# Altium schematic sheet FPGA_Power_Config.SchDoc
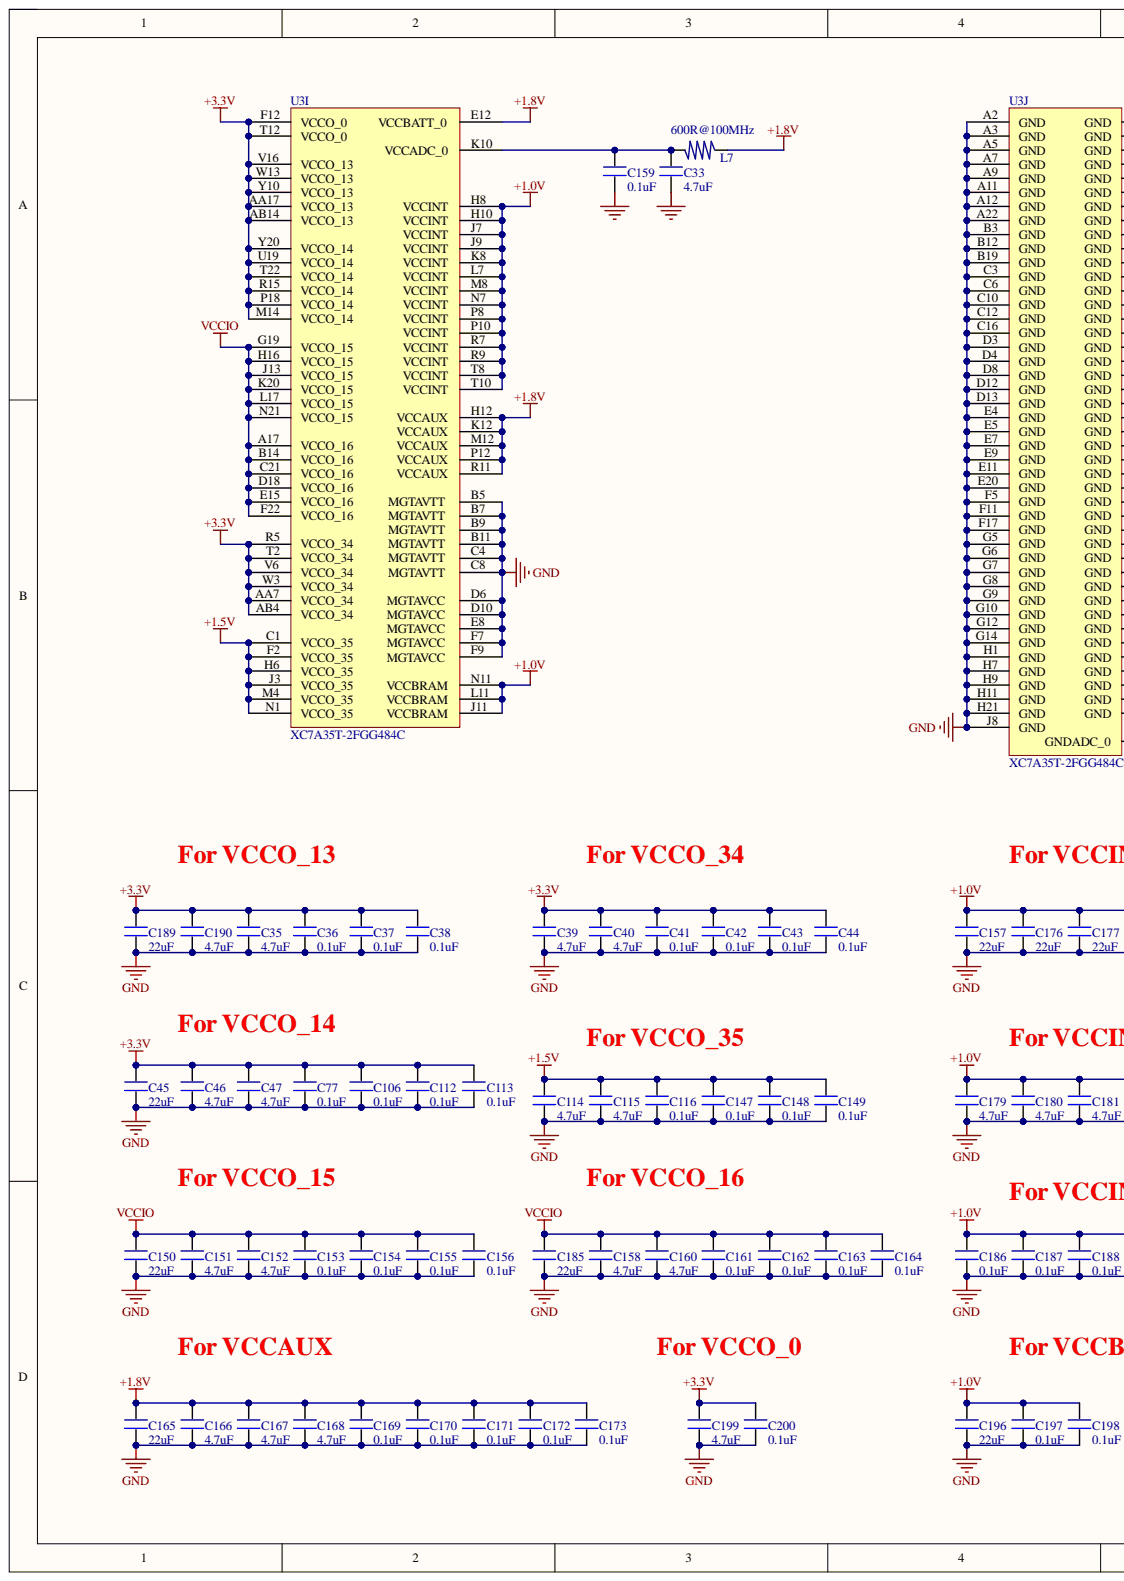
[diagram p0: FPGA_Power_Config.SchDoc, left]
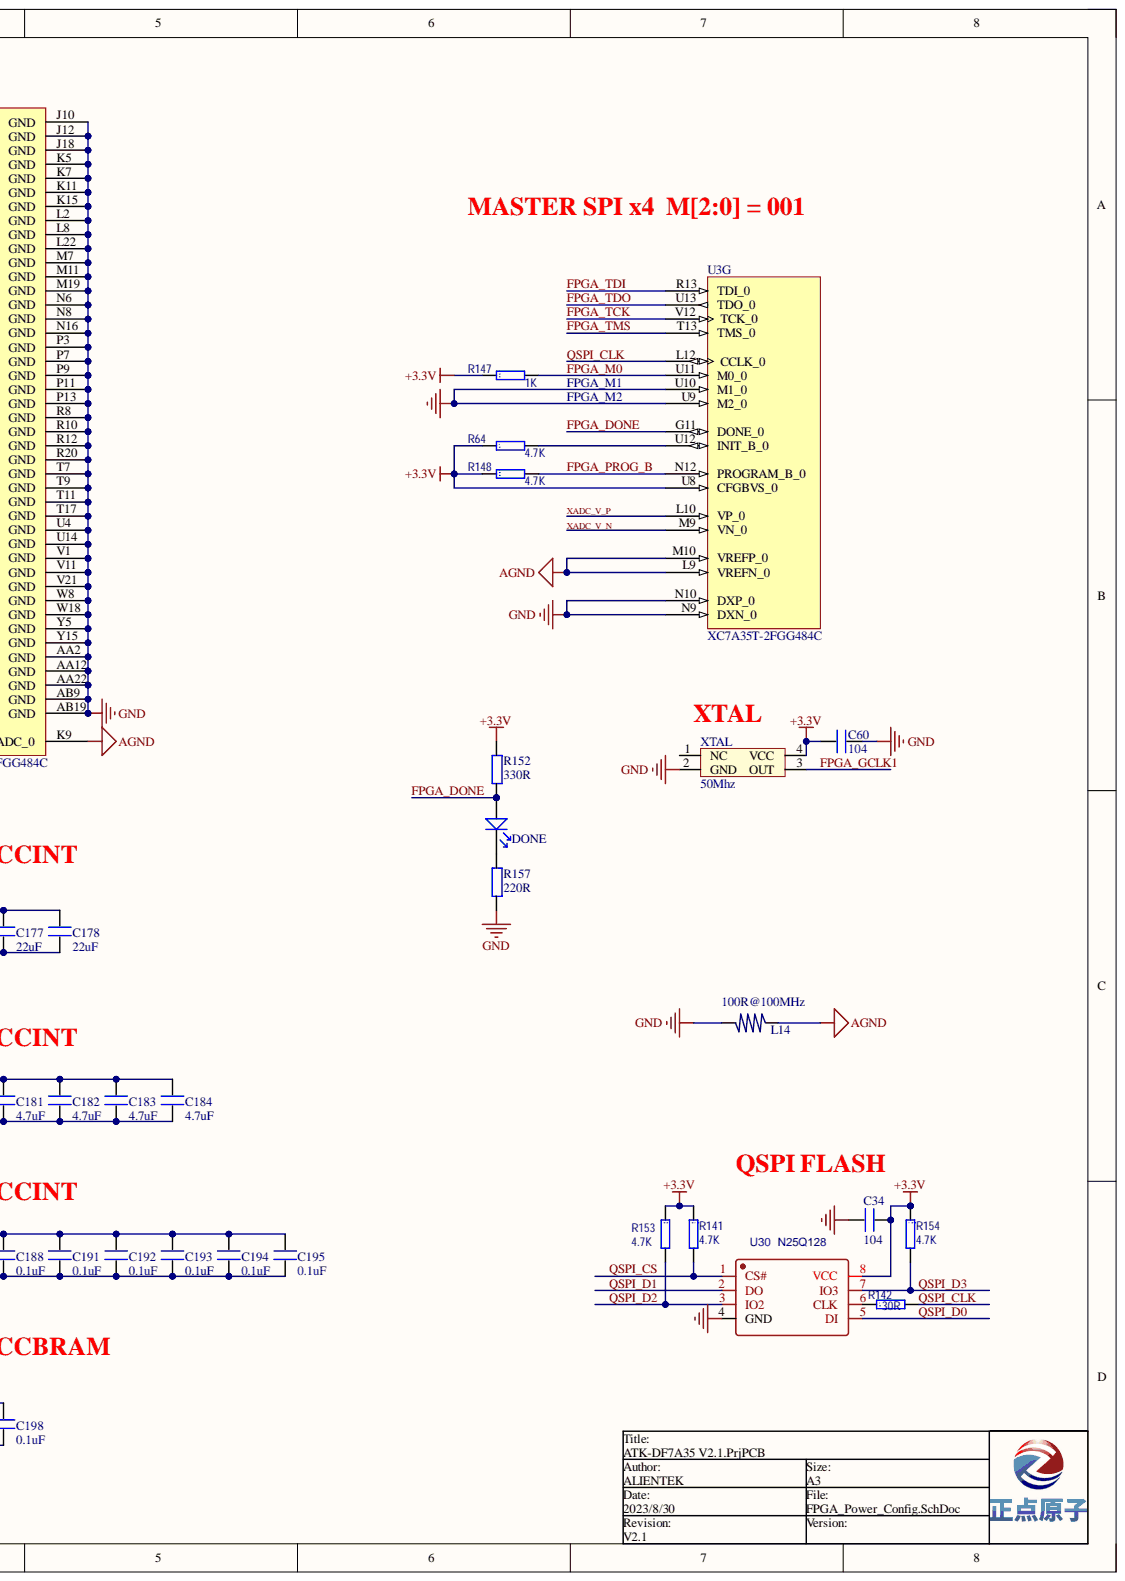
[diagram p1: FPGA_Power_Config.SchDoc, right]

## Parts
C33  4.7uF  [0402]
C34  104  [0603P]
C35  4.7uF  [0402]
C36  0.1uF  [0402]
C37  0.1uF  [0402]
C38  0.1uF  [0402]
C39  4.7uF  [0402]
C40  4.7uF  [0402]
C41  0.1uF  [0402]
C42  0.1uF  [0402]
C43  0.1uF  [0402]
C44  0.1uF  [0402]
C45  22uF  [0603P]
C46  4.7uF  [0402]
C47  4.7uF  [0402]
C60  104  [0603P]
C77  0.1uF  [0402]
C106  0.1uF  [0402]
C112  0.1uF  [0402]
C113  0.1uF  [0402]
C114  4.7uF  [0402]
C115  4.7uF  [0402]
C116  0.1uF  [0402]
C147  0.1uF  [0402]
C148  0.1uF  [0402]
C149  0.1uF  [0402]
C150  22uF  [0603P]
C151  4.7uF  [0402]
C152  4.7uF  [0402]
C153  0.1uF  [0402]
C154  0.1uF  [0402]
C155  0.1uF  [0402]
C156  0.1uF  [0402]
C157  22uF  [0603P]
C158  4.7uF  [0402]
C159  0.1uF  [0402]
C160  4.7uF  [0402]
C161  0.1uF  [0402]
C162  0.1uF  [0402]
C163  0.1uF  [0402]
C164  0.1uF  [0402]
C165  22uF  [0603P]
C166  4.7uF  [0402]
C167  4.7uF  [0402]
C168  4.7uF  [0402]
C169  0.1uF  [0402]
C170  0.1uF  [0402]
C171  0.1uF  [0402]
C172  0.1uF  [0402]
C173  0.1uF  [0402]
C176  22uF  [0603P]
C177  22uF  [0603P]
C178  22uF  [0603P]
C179  4.7uF  [0402]
C180  4.7uF  [0402]
C181  4.7uF  [0402]
C182  4.7uF  [0402]
C183  4.7uF  [0402]
C184  4.7uF  [0402]
C185  22uF  [0603P]
C186  0.1uF  [0402]
C187  0.1uF  [0402]
C188  0.1uF  [0402]
C189  22uF  [0603P]
C190  4.7uF  [0402]
C191  0.1uF  [0402]
C192  0.1uF  [0402]
C193  0.1uF  [0402]
C194  0.1uF  [0402]
C195  0.1uF  [0402]
C196  22uF  [0603P]
C197  0.1uF  [0402]
C198  0.1uF  [0402]
C199  4.7uF  [0603P]
C200  0.1uF  [0402]
DONE  PS_LED1  [SMDLED-0805]  (value not drawn)
L7  600R@100MHz  [0402]
L14  100R@100MHz  [0402]
R64  4.7K  [0603P]
R141  4.7K  [0603P]
R142  30R  [0603P]
R147  1K  [0603P]
R148  4.7K  [0603P]
R152  330R  [0603P]
R153  4.7K  [0603P]
R154  4.7K  [0603P]
R157  220R  [0603P]
U3  XC7A35T-2FGG484C  [FGG484]  (parts G,I,J)
U30  N25Q128  [SOIC-8_L5.3-W5.3-P1.27-LS8.0-BL]
XTAL  50Mhz  [XTAL3225]

## Nets
+1.0V: C157.1 C176.1 C177.1 C178.1 C179.1 C180.1 C181.1 C182.1 C183.1 C184.1 C186.1 C187.1 C188.1 C191.1 C192.1 C193.1 C194.1 C195.1 C196.1 C197.1 C198.1 U3.H8(VCCINT) U3.H10(VCCINT) U3.J7(VCCINT) U3.J9(VCCINT) U3.J11(VCCBRAM) U3.K8(VCCINT) U3.L7(VCCINT) U3.L11(VCCBRAM) U3.M8(VCCINT) U3.N7(VCCINT) U3.N11(VCCBRAM) U3.P8(VCCINT) U3.P10(VCCINT) U3.R7(VCCINT) U3.R9(VCCINT) U3.T8(VCCINT) U3.T10(VCCINT)
+1.5V: C114.1 C115.1 C116.1 C147.1 C148.1 C149.1 U3.C1(VCCO_35) U3.F2(VCCO_35) U3.H6(VCCO_35) U3.J3(VCCO_35) U3.M4(VCCO_35) U3.N1(VCCO_35)
+1.8V: C165.1 C166.1 C167.1 C168.1 C169.1 C170.1 C171.1 C172.1 C173.1 L7.1 U3.E12(VCCBATT_0) U3.H12(VCCAUX) U3.K12(VCCAUX) U3.M12(VCCAUX) U3.P12(VCCAUX) U3.R11(VCCAUX)
+3.3V: C34.1 C35.1 C36.1 C37.1 C38.1 C39.1 C40.1 C41.1 C42.1 C43.1 C44.1 C45.1 C46.1 C47.1 C60.2 C77.1 C106.1 C112.1 C113.1 C189.1 C190.1 C199.1 C200.1 R64.1 R141.1 R147.1 R148.1 R152.2 R153.1 R154.1 U3.AA7(VCCO_34) U3.AA17(VCCO_13) U3.AB4(VCCO_34) U3.AB14(VCCO_13) U3.F12(VCCO_0) U3.M14(VCCO_14) U3.P18(VCCO_14) U3.R5(VCCO_34) U3.R15(VCCO_14) U3.T2(VCCO_34) U3.T12(VCCO_0) U3.T22(VCCO_14) U3.U8(CFGBVS_0) U3.U19(VCCO_14) U3.V6(VCCO_34) U3.V16(VCCO_13) U3.W3(VCCO_34) U3.W13(VCCO_13) U3.Y10(VCCO_13) U3.Y20(VCCO_14) U30.8(VCC) XTAL.4(VCC)
AGND: L14.1 U3.K9(GNDADC_0) U3.L9(VREFN_0) U3.M10(VREFP_0)
GND: C33.2 C34.2 C35.2 C36.2 C37.2 C38.2 C39.2 C40.2 C41.2 C42.2 C43.2 C44.2 C45.2 C46.2 C47.2 C60.1 C77.2 C106.2 C112.2 C113.2 C114.2 C115.2 C116.2 C147.2 C148.2 C149.2 C150.2 C151.2 C152.2 C153.2 C154.2 C155.2 C156.2 C157.2 C158.2 C159.2 C160.2 C161.2 C162.2 C163.2 C164.2 C165.2 C166.2 C167.2 C168.2 C169.2 C170.2 C171.2 C172.2 C173.2 C176.2 C177.2 C178.2 C179.2 C180.2 C181.2 C182.2 C183.2 C184.2 C185.2 C186.2 C187.2 C188.2 C189.2 C190.2 C191.2 C192.2 C193.2 C194.2 C195.2 C196.2 C197.2 C198.2 C199.2 C200.2 L14.2 R157.1 U3.A2(GND) U3.A3(GND) U3.A5(GND) U3.A7(GND) U3.A9(GND) U3.A11(GND) U3.A12(GND) U3.A22(GND) U3.AA2(GND) U3.AA12(GND) U3.AA22(GND) U3.AB9(GND) U3.AB19(GND) U3.B3(GND) U3.B5(MGTAVTT) U3.B7(MGTAVTT) U3.B9(MGTAVTT) U3.B11(MGTAVTT) U3.B12(GND) U3.B19(GND) U3.C3(GND) U3.C4(MGTAVTT) U3.C6(GND) U3.C8(MGTAVTT) U3.C10(GND) U3.C12(GND) U3.C16(GND) U3.D3(GND) U3.D4(GND) U3.D6(MGTAVCC) U3.D8(GND) U3.D10(MGTAVCC) U3.D12(GND) U3.D13(GND) U3.E4(GND) U3.E5(GND) U3.E7(GND) U3.E8(MGTAVCC) U3.E9(GND) U3.E11(GND) U3.E20(GND) U3.F5(GND) U3.F7(MGTAVCC) U3.F9(MGTAVCC) U3.F11(GND) U3.F17(GND) U3.G5(GND) U3.G6(GND) U3.G7(GND) U3.G8(GND) U3.G9(GND) U3.G10(GND) U3.G12(GND) U3.G14(GND) U3.H1(GND) U3.H7(GND) U3.H9(GND) U3.H11(GND) U3.H21(GND) U3.J8(GND) U3.J10(GND) U3.J12(GND) U3.J18(GND) U3.K5(GND) U3.K7(GND) U3.K11(GND) U3.K15(GND) U3.L2(GND) U3.L8(GND) U3.L22(GND) U3.M7(GND) U3.M11(GND) U3.M19(GND) U3.N6(GND) U3.N8(GND) U3.N9(DXN_0) U3.N10(DXP_0) U3.N16(GND) U3.P3(GND) U3.P7(GND) U3.P9(GND) U3.P11(GND) U3.P13(GND) U3.R8(GND) U3.R10(GND) U3.R12(GND) U3.R20(GND) U3.T7(GND) U3.T9(GND) U3.T11(GND) U3.T17(GND) U3.U4(GND) U3.U9(M2_0) U3.U10(M1_0) U3.U14(GND) U3.V1(GND) U3.V11(GND) U3.V21(GND) U3.W8(GND) U3.W18(GND) U3.Y5(GND) U3.Y15(GND) U30.4(GND) XTAL.2(GND)
VCCIO: C150.1 C151.1 C152.1 C153.1 C154.1 C155.1 C156.1 C158.1 C160.1 C161.1 C162.1 C163.1 C164.1 C185.1 U3.A17(VCCO_16) U3.B14(VCCO_16) U3.C21(VCCO_16) U3.D18(VCCO_16) U3.E15(VCCO_16) U3.F22(VCCO_16) U3.G19(VCCO_15) U3.H16(VCCO_15) U3.J13(VCCO_15) U3.K20(VCCO_15) U3.L17(VCCO_15) U3.N21(VCCO_15)
FPGA_DONE: DONE.1 R152.1 U3.G11(DONE_0)
FPGA_GCLK1: XTAL.3(OUT)
FPGA_M0: R147.2 U3.U11(M0_0)
FPGA_PROG_B: R148.2 U3.N12(PROGRAM_B_0)
FPGA_TCK: U3.V12(TCK_0)
FPGA_TDI: U3.R13(TDI_0)
FPGA_TDO: U3.U13(TDO_0)
FPGA_TMS: U3.T13(TMS_0)
QSPI_CLK: R142.2 U3.L12(CCLK_0)
QSPI_CS: R141.2 U30.1(CS#)
QSPI_D0: U30.5(DI)
QSPI_D1: U30.2(DO)
QSPI_D2: R153.2 U30.3(IO2)
QSPI_D3: R154.2 U30.7(IO3)
XADC_V_N: U3.M9(VN_0)
XADC_V_P: U3.L10(VP_0)
NetC33_1: C33.1 C159.1 L7.2 U3.K10(VCCADC_0)
NetDONE_2: DONE.2 R157.2
NetR64_2: R64.2 U3.U12(INIT_B_0)
NetR142_1: R142.1 U30.6(CLK)

Not connected: XTAL 1(NC)

## Library data of the parts
C33-C47 C60 C77 C106 C112-C116 C147-C173 C176-C200 = C: Component Kind: Standard
  C33-C47 C77 C106 C112-C116 C147-C173 C176-C200: Library Name: MYCOMLIB.IntLib
  C60: Library Name: ALIENTEK_COMPONENT.IntLib
DONE = LED: Component Kind: Standard; Library Name: ALIENTEK_COMPONENT.IntLib
L7 L14 = L: Component Kind: Standard; Library Name: ZYNQ.SCHLIB
R64 R141 R142 R147 R148 R153 R154 = RES: Component Kind: Standard
  R64 R147 R148: Library Name: ZYNQ.SCHLIB
R152 R157 = R: Component Kind: Standard; Library Name: MYCOMLIB.IntLib
U3 = XC7A100T-2FGG484C: Artix-7 FPGA, 285 User I/Os, 4 GTP, 484-Ball BGA, Speed Grade 2, Commercial Grade, Pb-Free; Manufacturer: Xilinx; Block RAM Blocks (18Kb): 270; Block RAM Blocks (36Kb): 135; Block RAM Blocks (Max Kb): 4860; CLBs (Slices): 15850; Clock Mgmt Tiles (CMTs): 6; DSP48E1 Slices: 240; Logic Cells: 101440; Max User I/O: 300; Speed Grade: -2; CLBs (Max Distributed RAM Kb): 1188; Code_JEDEC: MS-034-AAJ-1; Component Kind: Standard; ComponentLink1Description: Datasheet; ComponentLink1URL: http://www.xilinx.com/support/documentation/data_sheets/ds180_7Series_Overview.pdf; ComponentLink2Description: Package Specification; ComponentLink2URL: http://www.xilinx.com/support/documentation/user_guides/ug475_7Series_Pkg_Pinout.pdf; ComponentLink3Description: DC and Switching Characteristics; ComponentLink3URL: http://www.xilinx.com/support/documentation/data_sheets/ds181_Artix_7_Data_Sheet.pdf; ComponentLink4Description: Package File; ComponentLink4URL: http://www.xilinx.com/support/packagefiles/a7packages/xc7a100tfgg484pkg.txt; ComponentLink5Description: Packaging and Pinout; ComponentLink5URL: http://www.xilinx.com/support/documentation/user_guides/ug475_7Series_Pkg_Pinout.pdf; DatasheetVersion: v1.12; Generic Part Number: XC7A100T; Library Name: Xilinx Artix-7.IntLib; Mounting Technology: Surface Mount; PackageDescription: Wire-Bond Fine-Pitch BGA, Body 23 x 23 mm, 1.0 mm Pitch; PackageReference: FGG484; PackageVersion: Rev 1.8; PartNumber: XC7A100T-2FGG484C; RoHS: Pb-Free
U30 = W25Q128JVSIQTR: BOM_Manufacturer: WINBOND; SMT Type: Basic; BOM_LCSC Assembly: Yes; BOM_Manufacturer Part: W25Q128JVSIQTR; BOM_Supplier: LCSC; BOM_Supplier Part: C97521; Component Kind: Standard; spicePre: U; spiceSymbolName: W25Q128JVSIQTR
XTAL = XTAL_S: 有源晶振; Component Kind: Standard; Library Name: ALIENTEK_COMPONENT.IntLib
